# Altium schematic sheet rc_gen.SchDoc
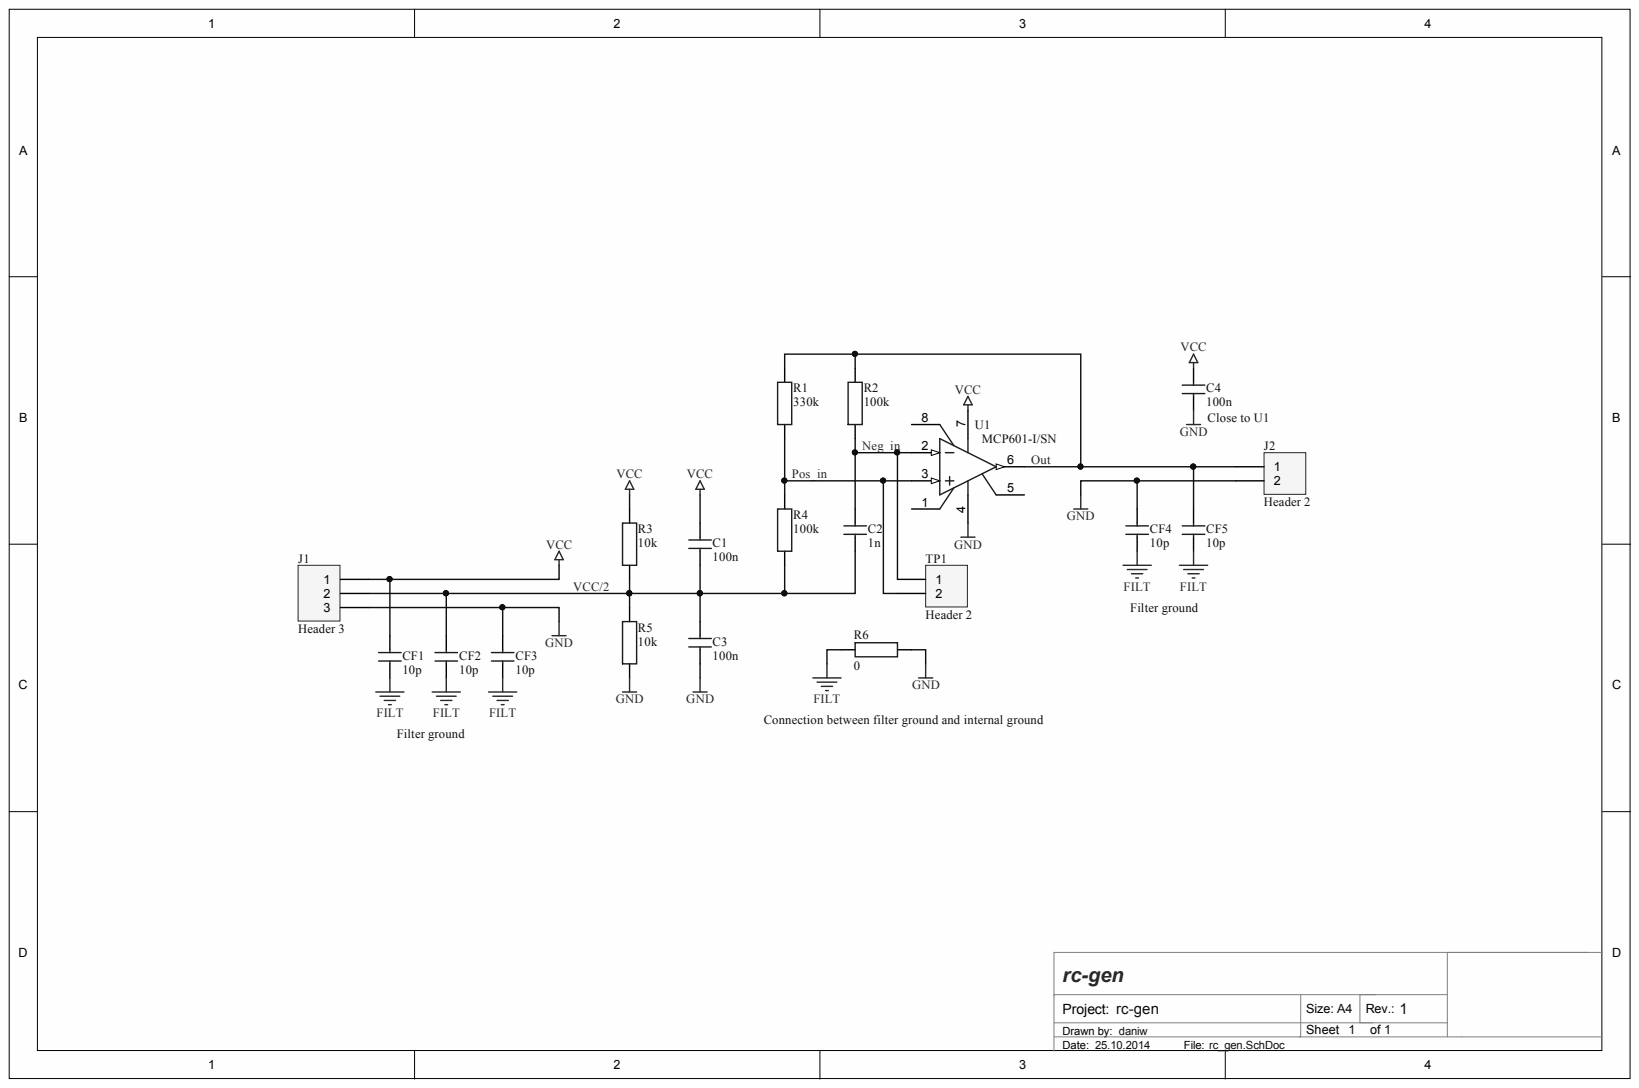
[diagram p0: rc_gen.SchDoc]

## Parts
C1  100n  [0805]
C2  1n  [0805]
C3  100n  [0805]
C4  100n  [0805]
CF1  10p  [0805]
CF2  10p  [0805]
CF3  10p  [0805]
CF4  10p  [0805]
CF5  10p  [0805]
J1  Header 3  [HDR1X3]
J2  Header 2  [HDR1X2]
R1  330k  [0805]
R2  100k  [0805]
R3  10k  [0805]
R4  100k  [0805]
R5  10k  [0805]
R6  0  [0805]
TP1  Header 2  [HDR1X2]
U1  MCP601-I/SN  [SOIC-SN8_N]

## Nets
FILT: CF1.2 CF2.2 CF3.2 CF4.2 CF5.2 R6.1
GND: C3.2 C4.2 CF3.1 CF4.1 J1.3 J2.2 R5.1 R6.2 U1.4
VCC: C1.1 C4.1 CF1.1 J1.1 R3.2 U1.7
NEG_IN: C2.1 R2.1 TP1.1 U1.2
OUT: CF5.1 J2.1 R1.2 R2.2 U1.6
POS_IN: R1.1 R4.2 TP1.2 U1.3
VCC/2: C1.2 C2.2 C3.1 CF2.1 J1.2 R3.1 R4.1 R5.2

Not connected: U1 1 5 8

## Library data of the parts
C1-C4 CF1-CF5 = C?: Normaler Kondensator; Component Kind: Standard; Library Name: DEVICE_HSLU.SchLib
  C1-C4: ClassName: circuit
  CF1-CF5: ClassName: filtermass
J1 = Header 3: Header, 3-Pin; ClassName: filtermass; Component Kind: Standard; LatestRevisionDate: 17-Jul-2002; LatestRevisionNote: Re-released for DXP Platform.; Library Name: Miscellaneous Connectors.IntLib; Signal Integrity: Connector; Publisher: Altium Limited
J2 TP1 = Header 2: Header, 2-Pin; Component Kind: Standard; LatestRevisionDate: 17-Jul-2002; LatestRevisionNote: Re-released for DXP Platform.; Library Name: Miscellaneous Connectors.IntLib; Signal Integrity: Connector; Publisher: Altium Limited
  J2: ClassName: filtermass
  TP1: ClassName: circuit
R1-R6 = R?: Normaler Widerstand; Component Kind: Standard; Library Name: DEVICE_HSLU.SchLib
  R1-R5: ClassName: circuit
  R6: ClassName: con_filt_circ
U1 = MCP601-I/SN: 2.7V to 6.0V Single Supply CMOS Operational Amplifier, 8-Pin SOIC, Industrial Temperature; ClassName: circuit; Code_IPC: SOIC127P600-8; Code_JEDEC: MS-012; Component Kind: Standard; ComponentLink1Description: Datasheet; ComponentLink1URL: http://ww1.microchip.com/downloads/en/DeviceDoc/21314g.pdf; ComponentLink2Description: Packaging Specification; ComponentLink2URL: http://www.microchip.com/stellent/groups/techpub_sg/documents/packagingspec/en012702.pdf; ComponentLink3Description: Microchip.com Home; ComponentLink3URL: http://www.microchip.com/; DatasheetVersion: revG, Dec-2007; Library Name: Microchip Linear Devices.IntLib; PackageDescription: 8-Lead Plastic Small Outline (SN) - Narrow, 3.90mm Body [SOIC]; PackageReference: SOIC-SN8; PackageVersion: revBB, Aug-2009; Published: 29-Jan-2009; Simulation: MCP601; Supplier 1: Digi-Key; Supplier 2: Farnell; Supplier Part Number 1: MCP601-I/SN-ND; Supplier Part Number 2: 9758623; Publisher: Altium Limited
